# Altium schematic sheet LPF & Distortion.SchDoc("LPF & Distortion")
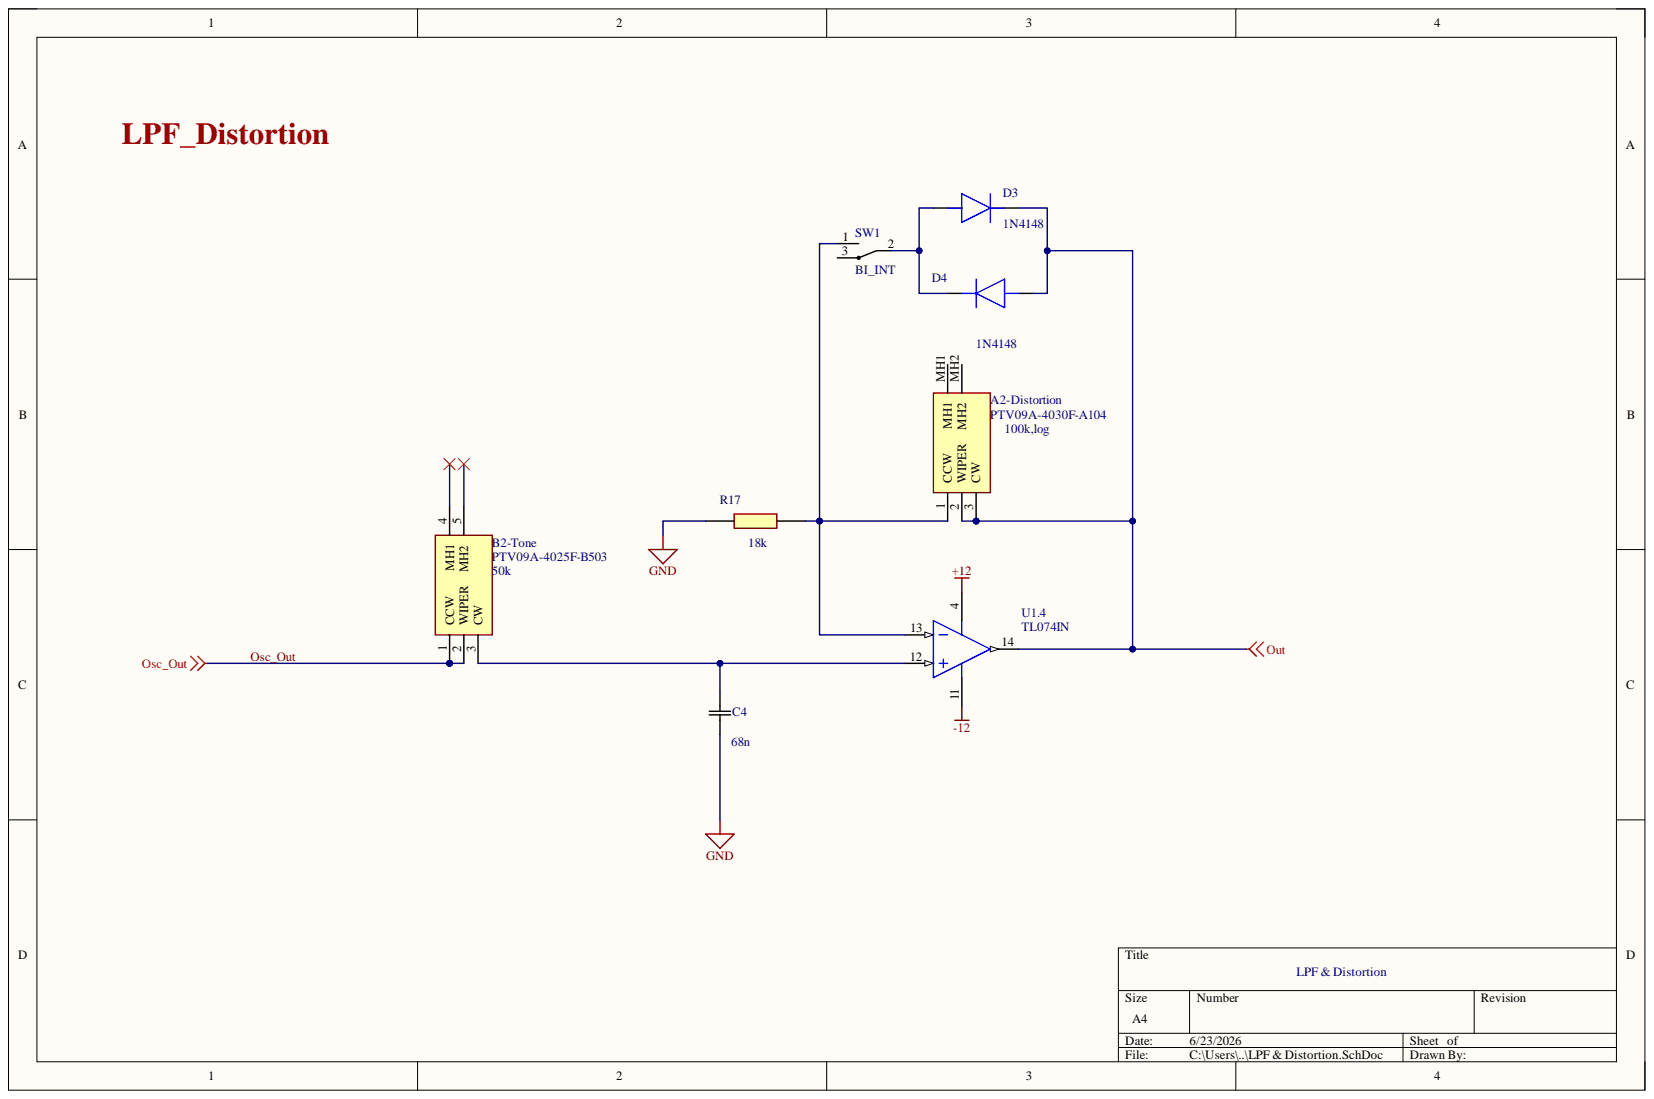
[diagram p0: LPF & Distortion.SchDoc]

## Parts
A2-Distortion  PTV09A-4030F-A104  value 100k,log  [PTV09A4025FB104]
B2-Tone  PTV09A-4025F-B503  value 50k  [PTV09A4025FB103]
C4  value 68n  [CAP_C320C_MR_X7R_KEM]  (hidden comment: C320C683J5R5TA)
D3  1N4148  [DIOAD1068W53L380D172]
D4  1N4148  [DIOAD1068W53L380D172]
R17  value 18k  [RESAD1590W60L630D240]  (hidden comment: MFR-25FTF52-600K)
SW1  BI_INT  [INT_BI]
U1  TL074IN  [N0014A]  (part .4)

## Nets
GND: C4.2 R17.1
Out: A2-Distortion.2(WIPER) A2-Distortion.3(CW) D3.1 D4.2 U1.14
Osc_Out: B2-Tone.1(CCW) B2-Tone.2(WIPER)
NetA2-Distortion_1: A2-Distortion.1(CCW) R17.2 SW1.1 U1.13
NetB2-Tone_3: B2-Tone.3(CW) C4.1 U1.12
NetD3_2: D3.2 D4.1 SW1.2
+12:
-12:

Not connected: A2-Distortion MH1 MH2; B2-Tone 4(MH1) 5(MH2); SW1 3; U1 4 11

## Library data of the parts
A2-Distortion = CMP-032-000030-1: Variable Resistor; Manufacturer_Name: Bourns; Manufacturer_Part_Number: PTV09A-4025F-B104; Arrow Part Number: PTV09A-4025F-B104; Arrow Price/Stock: https://www.arrow.com/en/products/ptv09a-4025f-b104/bourns?region=nac; Component Kind: Standard; Datasheet Link: https://www.digikey.ph/en/products/detail/bourns-inc/PTV09A-4025F-B104/3820577?msockid=39f1ec84d77b6f4428b7f904d6676eed; Design Item ID: PTV09A-4025F-B104; Height: 25.5mm; Mouser Part Number: 652-PTV09A4025FB104; Mouser Price/Stock: https://www.mouser.co.uk/ProductDetail/Bourns/PTV09A-4025F-B104?qs=fgHA1UgJI8DoC1GZkaXClg%3D%3D; Source: PTV0910k.SchLib; Library Name: PoliTeK
B2-Tone = CMP-032-000031-1: Variable Resistor; Manufacturer_Name: Bourns; Manufacturer_Part_Number: PTV09A-4025F-B103; Arrow Part Number: PTV09A-4025F-B103; Arrow Price/Stock: null?region=nac; Component Kind: Standard; Datasheet Link: https://www.bourns.com/docs/Product-Datasheets/PTV09.pdf; Design Item ID: PTV09A-4025F-B103; Height: 25.5mm; Mouser Part Number: 652-PTV09A-4025FB103; Mouser Price/Stock: https://www.mouser.co.uk/ProductDetail/Bourns/PTV09A-4025F-B103?qs=okKRLHKnSlbwMbAO07mw0g%3D%3D; Source: PTV0910k.SchLib; Library Name: PoliTeK
C4 = CMP-001-00092-1: 68 nF ceramic Capacitor; Mfr_Name: Kemet; Component Kind: Standard; Component Type: Standard; Design Item ID: C320C683J5R5TA; Pins: 2; Source: C320C683J5R5TA.SchLib; Library Name: EMEA - Politecnico di Torino - PoliTek Music
D3 D4 = CMP-004-00032-1: Small Signal Diode; Manufacturer_Name: onsemi; Arrow Part Number: 1N4148; Arrow Price/Stock: https://www.arrow.com/en/products/1n4148/on-semiconductor?region=europe; Component Kind: Standard; Component Type: Standard; Datasheet Link: https://www.onsemi.com/pub/Collateral/1N914-D.PDF; Design Item ID: 1N4148; Manufacturer_Part_Number: 1N4148; Mouser Part Number: 512-1N4148; Mouser Price/Stock: https://www.mouser.co.uk/ProductDetail/onsemi-Fairchild/1N4148?qs=i4Fj9T%2FoRm8RMUhj5DeFQg%3D%3D; Pins: 2; Source: SamacSys.SchLib; Library Name: EMEA - Politecnico di Torino - PoliTek Music
R17 = CMP-009-00182-2: Metal Film Resistors - Through Hole; Manufacturer_Name: YAGEO; Component Kind: Standard; Component Type: Standard; Datasheet Link: https://www.mouser.sg/datasheet/2/447/YAGEO_MFR_datasheet_2023v3-3324391.pdf; Design Item ID: MFR-25FTF52-600K; Manufacturer_Part_Number: MFR-25FTF52-600K; Mouser Part Number: 603-MFR-25FTF52-600K; Mouser Price/Stock: https://www.mouser.co.uk/ProductDetail/YAGEO/MFR-25FTF52-600K?qs=xZ%2FP%252Ba9zWqYtTZdHR75SUg%3D%3D; Pins: 2; Source: SamacSys.SchLib; Library Name: EMEA - Politecnico di Torino - PoliTek Music
SW1 = CMP-011-00014-1: Toggle Switches BUSHING MOUNT MINIATURE TOGGLE; Mfr.: NKK Switches; Mfr. No: MN12SS4W01; Component Kind: Standard; Component Type: Standard; ComponentLink1Description: datasheet; ComponentLink1URL: https://www.mouser.it/datasheet/2/295/MN_ToggleSections_DP-3389170.pdf; Design Item ID: BI_INT; Pins: 3; Source: INT_BI.SchLib; Library Name: EMEA - Politecnico di Torino - PoliTek Music
U1 = CMP-0017-00871-2: Quad Low-Noise JFET-Input General-Purpose Operational Amplifier, 7 to 36 V, -40 to 85 degC, 14-pin DIP (N14), Pb-Free (RoHS); Manufacturer: Texas Instruments; CMRR(Min)(dB): 70; GBW(Typ)(MHz): 3; IIB(Max)(pA): 200; Iq per channel(Max)(mA): 2.5; Number of Channels: 4; Offset Drift(Typ)(uV/C): 18; Rating: Military; Slew Rate(Typ)(V/us): 13; Sub Family: General Purpose Amplifier, High Supply Voltage Amplifier (>=30V); Total Supply Voltage(Max)(+5V is 5, +/-5V is 10): 36; Total Supply Voltage(Min)(+5V is 5, +/-5V is 10): 7; Vio (25C)(Max)(mV): 10; Code_JEDEC: MS-001; Component Kind: Standard; ComponentLink1Description: Manufacturer URL; ComponentLink1URL: http://www.ti.com/; ComponentLink2Description: Datasheet; ComponentLink2URL: http://www.ti.com/lit/ds/symlink/tl074.pdf; ComponentLink3Description: Package Specification; ComponentLink3URL: http://www.ti.com/lit/ml/mpdi002c/mpdi002c.pdf; DatasheetVersion: SLOS080J; Eco-Plan: Pb-Free (RoHS); Library Name: Altium Content Vault; Mounting Technology: Through Hole; PackageDescription: 14-Pin Plastic In-Line, Body 19.305 x 6.35 mm, Pitch 2.54 mm; PackageReference: N0014A; PackageVersion: 4040049/E, 12/2002; PartNumber: TL074IN; Vn at 1kHz(Typ)(nV/rtHz): 18
